# Altium schematic sheet TPVSI_Power&DA.SchDoc(TPVSI_Power&DA)
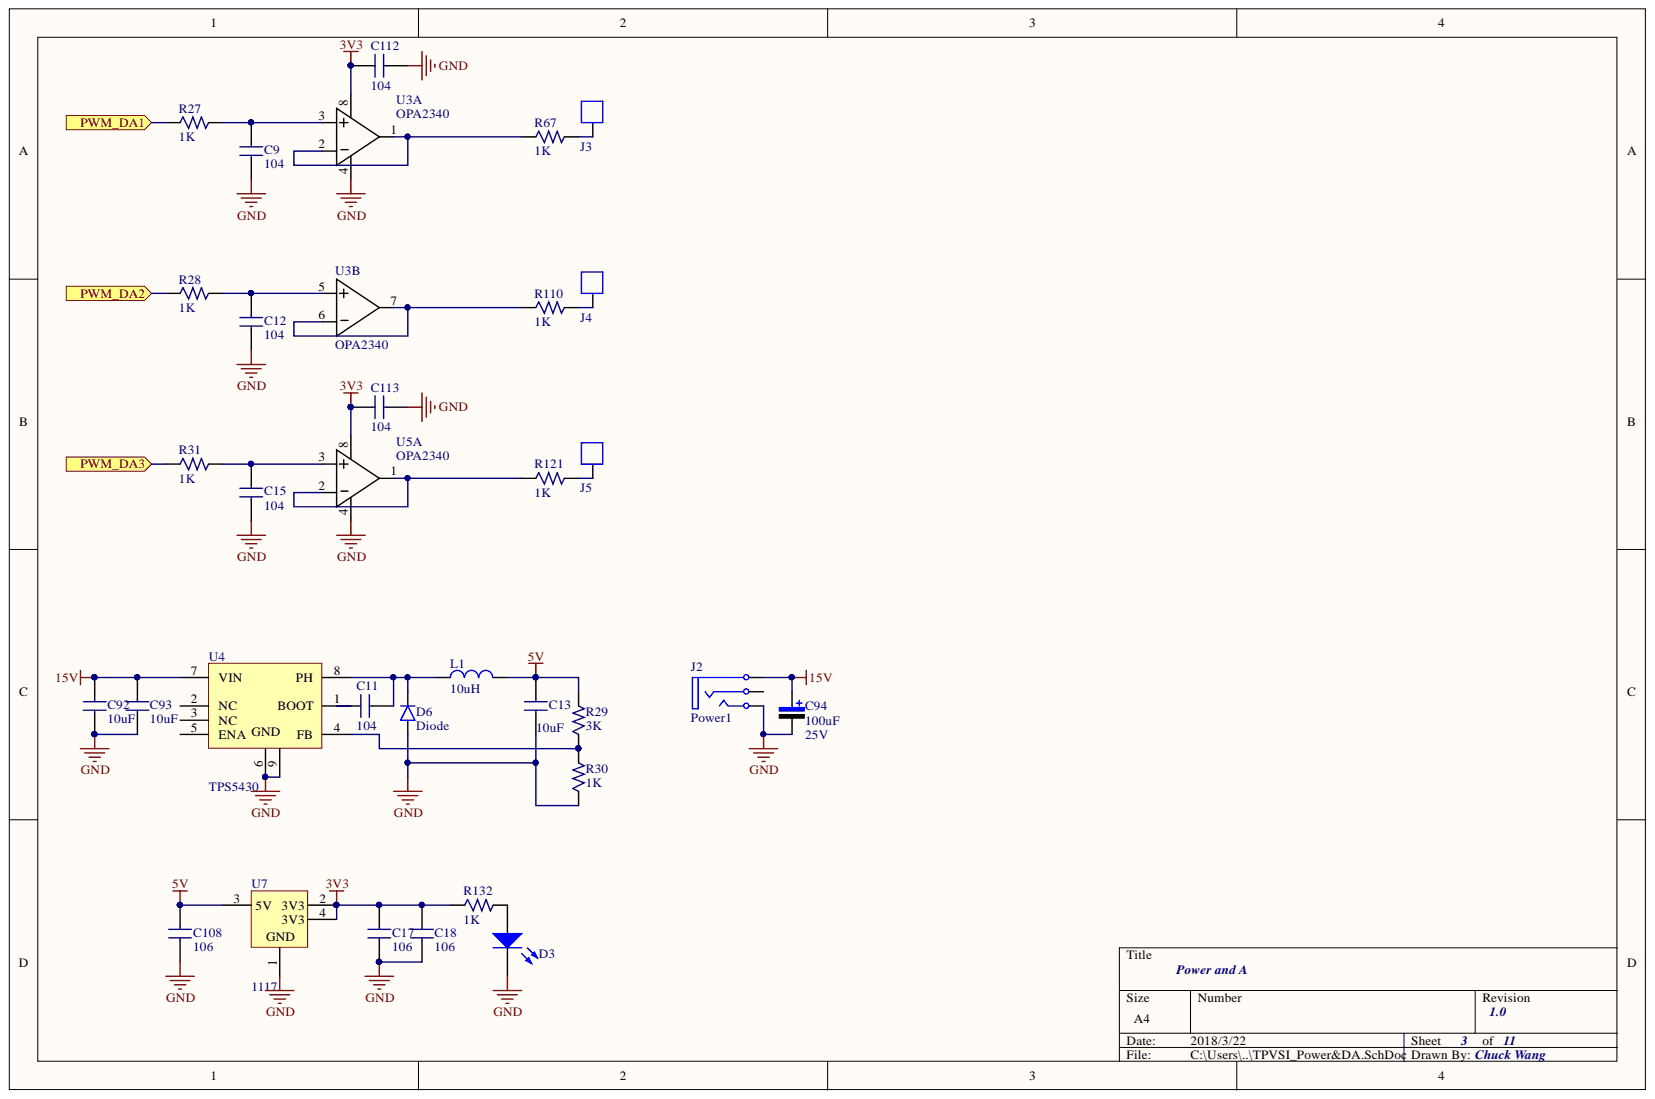
[diagram p0: TPVSI_Power&DA.SchDoc]

## Parts
C9  104  [C-RAD-0603]
C11  104  [C-RAD-0603]
C12  104  [C-RAD-0603]
C13  10uF  [1210]
C15  104  [C-RAD-0603]
C17  106  [C-RAD-0603]
C18  106  [C-RAD-0603]
C92  10uF  [1210]
C93  10uF  [1210]
C94  value 100uF  Vol: 25V  [C-RB-8]  (hidden comment: Cap Pol)
C108  106  [C-RAD-0603]
C112  104  [C-RAD-0603]
C113  104  [C-RAD-0603]
D3  LED0  [LED_0805]  (value not drawn)
D6  Diode  [DIODE-SMA]
J2  Power1  [9VPOWER]
J3  1H  [PIN1]  (value not drawn)
J4  1H  [PIN1]  (value not drawn)
J5  1H  [PIN1]  (value not drawn)
L1  value 10uH  [L-SMD-7]  (hidden comment: Inductor)
R27  1K  [0603_1]
R28  1K  [0603_1]
R29  3K  [0603_1]
R30  1K  [0603_1]
R31  1K  [0603_1]
R67  1K  [0603_1]
R110  1K  [0603_1]
R121  1K  [0603_1]
R132  1K  [0603_1]
U3  OPA2340  [SOIC8_N]  (parts A,B)
U4  TPS5430  [SOIC8_EXPOSEDPAD]
U5  OPA2340  [SOIC8_N]  (part A)
U7  1117  [SOT223]

## Ports
PWM_DA1, PWM_DA2, PWM_DA3

## Nets
3V3: C17.2 C18.2 C112.1 C113.1 R132.1 U3.8 U5.8 U7.2(3V3) U7.4(3V3)
5V: C13.2 C108.2 L1.2 R29.2 U7.3(5V)
15V: C92.2 C93.2 C94.1 J2.3 U4.7(VIN)
GND: C9.1 C12.1 C13.1 C15.1 C17.1 C18.1 C92.1 C93.1 C94.2 C108.1 C112.2 C113.2 D3.2 D6.2 J2.1 R30.1 U3.4 U4.6 U4.9 U5.4 U7.1
PWM_DA1: R27.1 ; port PWM_DA1
PWM_DA2: R28.1 ; port PWM_DA2
PWM_DA3: R31.1 ; port PWM_DA3
NetC9_2: C9.2 R27.2 U3.3
NetC11_1: C11.1 U4.1(BOOT)
NetC11_2: C11.2 D6.1 L1.1 U4.8(PH)
NetC12_2: C12.2 R28.2 U3.5
NetC15_2: C15.2 R31.2 U5.3
NetD3_1: D3.1 R132.2
NetJ3_1: J3.1 R67.2
NetJ4_1: J4.1 R110.2
NetJ5_1: J5.1 R121.2
NetR29_1: R29.1 R30.2 U4.4(FB)
NetR67_1: R67.1 U3.1 U3.2
NetR110_1: R110.1 U3.6 U3.7
NetR121_1: R121.1 U5.1 U5.2

Not connected: J2 2; U4 2(NC) 3(NC) 5(ENA)

## Library data of the parts
C9 C11-C13 C15 C17 C18 C92 C93 C108 C112 C113 = cap: Component Kind: Standard; Library Name: Chu_16.IntLib
C94 = Cap Pol: 极性电解电容; Published: 8-Jun-2000; PackageReference: RB7.6-15; LatestRevisionNote: Re-released for DXP Platform.; LatestRevisionDate: 17-Jul-2002; Component Kind: Standard; Publisher: Altium Limited; Library Name: Fszhang.IntLib
D3 = LED0: 发光二极管; Published: 8-Jun-2000; PackageReference: LED-0; LatestRevisionNote: Mech Layer 1 removed.; LatestRevisionDate: 08-Jul-2005; Component Kind: Standard; Publisher: Altium Limited; Library Name: Fszhang.IntLib
D6 = Diode_Schottkey: Component Kind: Standard; Library Name: Chu_16.IntLib
J2 = Power1: Jack Socket, 1/4" [6.5mm], Thru-Hole, Vertical, 3-Conductor Open Circuit (Non-Normalling); PCB_Layout: Complies with manufacturer's recommendation.; PackageDocument: 2003; DrillAndSlotSize: Corresponds to nominal value.; Published: 15-Jul-2004; PackageReference: S1VS_SSS; LatestRevisionNote: Component Replaced.; LatestRevisionDate: 15-Jul-2004; DatasheetDocument: 2003; ComponentLink1URL: http://www.cliffuk.co.uk/; ComponentLink1Description: Manufacturer Link; Component Kind: Standard; Publisher: Altium Limited; Library Name: 机器人队元件库 .IntLib
L1 = Inductor: 电感; Code_IEC: 1005; Published: 8-Jun-2000; PackageReference: 0402-A; LatestRevisionNote: Re-released for DXP Platform.; LatestRevisionDate: 17-Jul-2002; Component Kind: Standard; Code_JEITA: 0402; Publisher: Altium Limited; Library Name: Fszhang.IntLib
R27-R31 R67 R110 R121 R132 = Res: Component Kind: Standard; Library Name: Chu_16.IntLib
J3-J5 = 1H: Component Kind: Standard; Library Name: 机器人队元件库 .IntLib
U3 U5 = OPA2340: Description: OPA2340; Component Kind: Standard; Library Name: Chu_16.IntLib
U4 = TPS5430: Component Kind: Standard; Library Name: Chu_16.IntLib
U7 = 1117: Component Kind: Standard; Library Name: Chu_16.IntLib
